# Altium schematic sheet MAIN.SchDoc(MAIN)
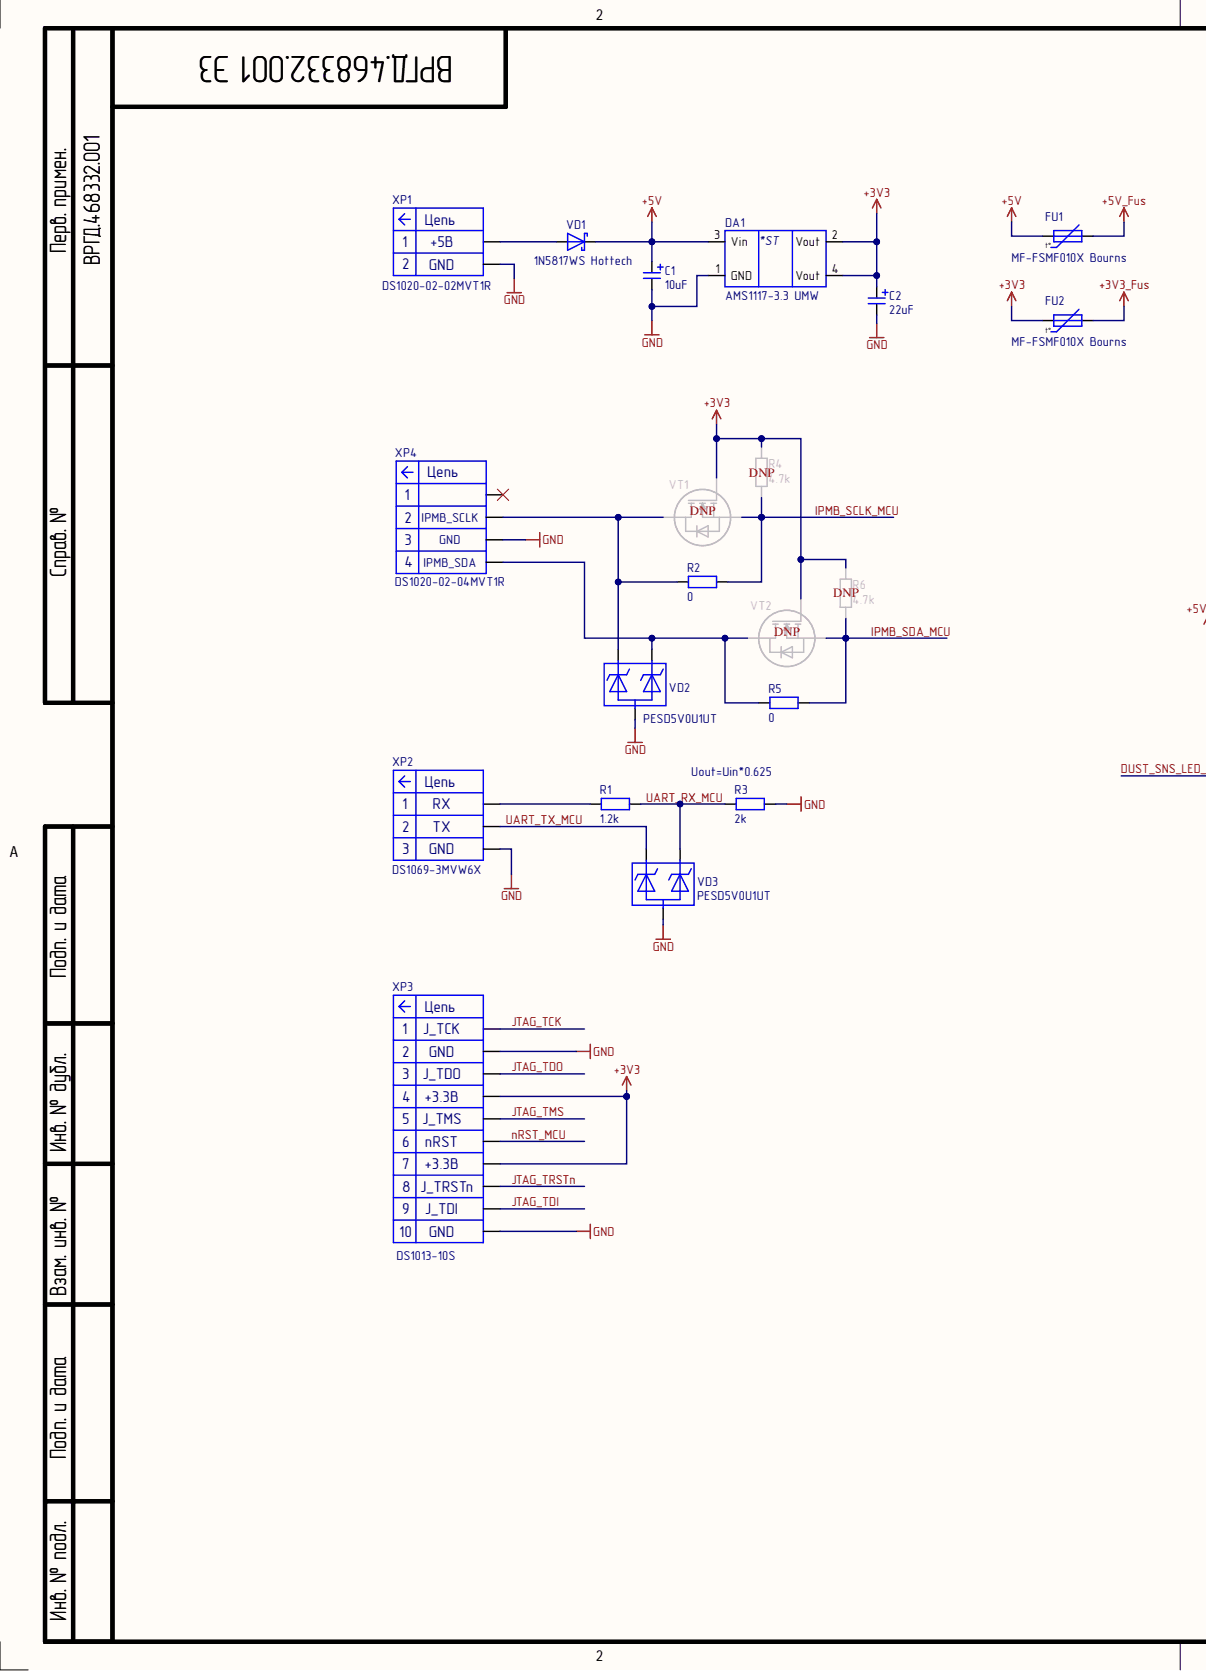
[diagram p0: MAIN.SchDoc, left]
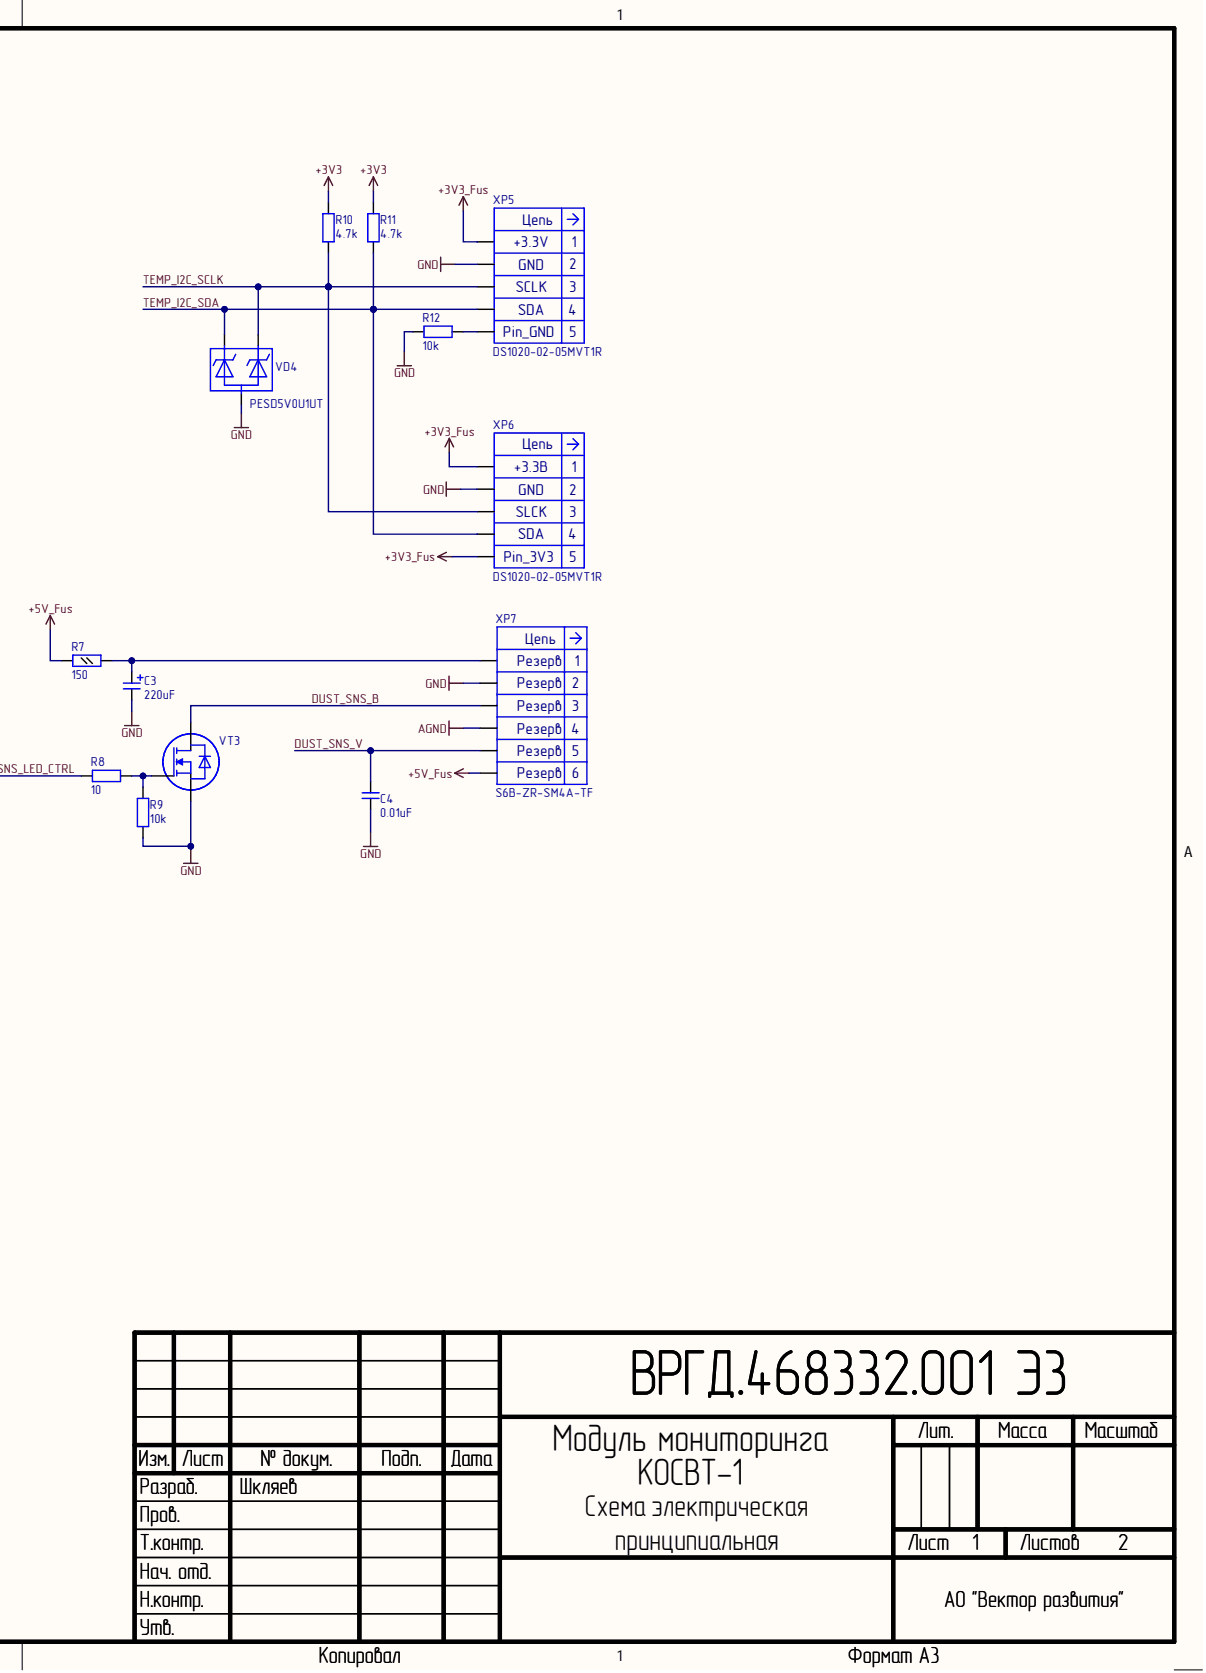
[diagram p1: MAIN.SchDoc, right]

## Parts
C1  10uF  [CAP ALSMD ELEC 4.3MM H5.4MM]
C2  22uF  [CAP ALSMD ELEC 4.3MM H5.4MM]
C3  220uF  [CAP ALSMD ELEC 6.6MM H7.7MM]
C4  0.01uF  [CAP 0603_1608]
DA1  AMS1117-3.3 UMW  Manufacturer: UMW  Name: AMS1117-3.3  [DIODES SOT-223]
FU1  MF-FSMF010X Bourns  Manufacturer: Bourns  Name: MF-FSMF010X  [FUSE 0603_1608 0.5MM]
FU2  MF-FSMF010X Bourns  Manufacturer: Bourns  Name: MF-FSMF010X  [FUSE 0603_1608 0.5MM]
R1  1.2k  [RES 0603_1608]
R2  0  [RES 0603_1608]
R3  2k  [RES 0603_1608]
R4  4.7k  [RES 0603_1608]
R5  0  [RES 0603_1608]
R6  4.7k  [RES 0603_1608]
R7  150  [RES 0805_2012]
R8  10  [RES 0603_1608]
R9  10k  [RES 0603_1608]
R10  4.7k  [RES 0603_1608]
R11  4.7k  [RES 0603_1608]
R12  10k  [RES 0603_1608]
VD1  1N5817WS Hottech  Manufacturer: Hottech  Name: 1N5817WS  [MCC SOD-323]
VD2  PESD5V0U1UT  [SOT-23-3 N]
VD3  PESD5V0U1UT  [SOT-23-3 N]
VD4  PESD5V0U1UT  [SOT-23-3 N]
VT1  2П526А9 АЕЯР.432140.897ТУ  [SOT-23-3 N]  (value not drawn)
VT2  2П526А9 АЕЯР.432140.897ТУ  [SOT-23-3 N]  (value not drawn)
VT3  2П526А9 АЕЯР.432140.897ТУ  [SOT-23-3 N]  (value not drawn)
XP1  DS1020-02-02MVT1R  Net_1: +5В  Net_2: GND  [DS1020-02-02MVT1R]
XP2  DS1069-3MVW6X  Net_1: RX  Net_2: TX  Net_3: GND  [CONNFLY_DS1069-3M]
XP3  DS1013-10S  Net_1: J_TCK  Net_2: GND  Net_3: J_TDO  Net_4: +3.3В  Net_5: J_TMS  Net_6: nRST  Net_8: J_TRSTn  Net_9: J_TDI  [DS1013-10S]
XP4  DS1020-02-04MVT1R  Net_2: IPMB_SCLK  Net_3: GND  Net_4: IPMB_SDA  [DS1020-02-04MVT1R]
XP5  DS1020-02-05MVT1R  Net_1: +3.3V  Net_2: GND  Net_3: SCLK  Net_4: SDA  Net_5: Pin_GND  [DS1020-02-05MVT1R]
XP6  DS1020-02-05MVT1R  Net_1: +3.3В  Net_2: GND  Net_3: SLCK  Net_4: SDA  Net_5: Pin_3V3  [DS1020-02-05MVT1R]
XP7  S6B-ZR-SM4A-TF  Net_1: Резерв  [JST S6B-ZR-SM4A-TF]

## Nets
+3V3: C2.1 DA1.2(Vout) DA1.4(Vout) FU2.1 R4.2 R6.2 R10.2 R11.2 VT1.1 VT2.1 XP3.4(+3.3В) XP3.7(+3.3В)
+3V3_Fus: FU2.2 XP5.1(+3.3V) XP6.1(+3.3В) XP6.5(Pin_3V3)
+5V: C1.1 DA1.3(Vin) FU1.1 VD1.2
+5V_Fus: FU1.2 R7.1 XP7.6(Резерв)
AGND: XP7.4(Резерв)
GND: C1.2 C2.2 C3.2 C4.2 DA1.1(GND) R3.2 R9.1 R12.2 VD2.3 VD3.3 VD4.3 VT3.3 XP1.2 XP2.3 XP3.2 XP3.10 XP4.3 XP5.2(GND) XP6.2(GND) XP7.2(Резерв)
DUST_SNS_B: VT3.2 XP7.3(Резерв)
DUST_SNS_LED_CTRL: R8.1
DUST_SNS_V: C4.1 XP7.5(Резерв)
IPMB_SCLK_MCU: R2.1 R4.1 VT1.3
IPMB_SDA_MCU: R5.1 R6.1 VT2.3
JTAG_TCK: XP3.1(J_TCK)
JTAG_TDI: XP3.9(J_TDI)
JTAG_TDO: XP3.3(J_TDO)
JTAG_TMS: XP3.5(J_TMS)
JTAG_TRSTn: XP3.8(J_TRSTn)
nRST_MCU: XP3.6(nRST)
TEMP_I2C_SCLK: R10.1 VD4.2 XP5.3(SCLK) XP6.3(SLCK)
TEMP_I2C_SDA: R11.1 VD4.1 XP5.4(SDA) XP6.4(SDA)
UART_RX_MCU: R1.2 R3.1 VD3.2
UART_TX_MCU: VD3.1 XP2.2
NetC3_1: C3.1 R7.2 XP7.1(Резерв)
NetR1_1: R1.1 XP2.1
NetR2_2: R2.2 VD2.1 VT1.2 XP4.2(IPMB_SCLK)
NetR5_2: R5.2 VD2.2 VT2.2 XP4.4(IPMB_SDA)
NetR8_2: R8.2 R9.2 VT3.1
NetR12_1: R12.1 XP5.5(Pin_GND)
NetVD1_1: VD1.1 XP1.1

Not connected: XP4 1

## Library data of the parts
C1 = К50-97 – 25В – 10мкФ (±20) % – ЕВАЯ.673541.054ТУ: Конденсатор К50-97 – 25В – 10мкФ (±20) % – ЕВАЯ.673541.054ТУ; Manufacturer: АО Элеконд; Database Table Name: capacitors.csv; Dielectric type: Electrolytic; Group: Конденсаторы полярные; Tolerance: ± 20%; Type: Конденсатор электролитический; Voltage: 25V; Component Kind: Standard; Country: Россия; HelpURL: https://elecond.ru/pdf/k50-97.pdf; Library Name: ComponentsDb.DbLib; Manufacturer Part Number: К50-97 – 25В – 10мкФ (±20) % – ЕВАЯ.673541.054ТУ; Name: К50-97 – 25В – 10мкФ (±20) % – ЕВАЯ.673541.054ТУ; Package: SMD D4x5.4; Value: 10uF
C2 = К50-97 – 16В – 22мкФ (±20) % – ЕВАЯ.673541.054ТУ: Конденсатор К50-97 – 16В – 22мкФ (±20) % – ЕВАЯ.673541.054ТУ; Manufacturer: АО Элеконд; Database Table Name: capacitors.csv; Dielectric type: Electrolytic; Group: Конденсаторы полярные; Tolerance: ± 20%; Type: Конденсатор электролитический; Voltage: 16V; Component Kind: Standard; Country: Россия; HelpURL: https://elecond.ru/pdf/k50-97.pdf; Library Name: ComponentsDb.DbLib; Manufacturer Part Number: К50-97 – 16В – 22мкФ (±20) % – ЕВАЯ.673541.054ТУ; Name: К50-97 – 16В – 22мкФ (±20) % – ЕВАЯ.673541.054ТУ; Package: SMD D4x5.4; Value: 22uF
C3 = К50-97 – 16В – 220мкФ (±20) % – ЕВАЯ.673541.054ТУ: Конденсатор К50-97 – 16В – 220мкФ (±20) % – ЕВАЯ.673541.054ТУ; Manufacturer: АО Элеконд; Database Table Name: capacitors.csv; Dielectric type: Electrolytic; Group: Конденсаторы полярные; Tolerance: ± 20%; Type: Конденсатор электролитический; Voltage: 16V; Component Kind: Standard; Country: Россия; HelpURL: https://elecond.ru/pdf/k50-97.pdf; Library Name: ComponentsDb.DbLib; Manufacturer Part Number: К50-97 – 16В – 220мкФ (±20) % – ЕВАЯ.673541.054ТУ; Name: К50-97 – 16В – 220мкФ (±20) % – ЕВАЯ.673541.054ТУ; Package: SMD D6.3x7.7; Value: 220uF
C4 = К10-83 - 50 В - 0,01 мкФ ±20 % - Н20 - N - 1 АЖЯР.673511.008 ТУ: Конденсатор К10-83 - 50 В - 0,01 мкФ ±20 % - Н20 - N - 1 АЖЯР.673511.008 ТУ; Manufacturer: АО «НИИ «Гириконд»; Database Table Name: capacitors.csv; Group: Конденсаторы; Tolerance: ± 20%; Type: Конденсатор керамический; Voltage: 50V; Component Kind: Standard; Country: Россия; Dielectric type: Н20; HelpURL: https://giricond.ru/upload/pdf/K/K10-83.pdf; Library Name: ComponentsDb.DbLib; Manufacturer Part Number: К10-83 - 50 В - 0,01 мкФ ±20 % - Н20 - N - 1 АЖЯР.673511.008 ТУ; Name: К10-83 - 50 В - 0,01 мкФ ±20 % - Н20 - N - 1 АЖЯР.673511.008 ТУ; Package: SMD0603; Value: 0.01uF
DA1 = AMS1117-3.3 UMW: Микросхема AMS1117-3.3; Database Table Name: regulator_ics.csv; Group: Микросхемы; Input Voltage: 4.5 to 12V; Max Temperature: 125°С; Min Temperature: -40°С; Output Current: 1A; Output Voltage: 3.3V; Component Kind: Standard; Country: Китай; HelpURL: https://www.lcsc.com/datasheet/lcsc_datasheet_2412201207_UMW-Youtai-Semiconductor-Co---Ltd--AMS1117-3-3_C347222.pdf; Library Name: ComponentsDb.DbLib; Manufacturer Part Number: AMS1117-3.3 UMW; Package: SOT-223; Type: Линейный регулятор
FU1 FU2 = MF-FSMF010X Bourns: Предохранитель самовосстанавливающийся MF-FSMF010X; Database Table Name: fuses.csv; Group: Предохранители; I hold: 0.1A; I trip: 0.3A; V: 15V; Component Kind: Standard; Country: США; HelpURL: https://static.chipdip.ru/lib/299/DOC012299906.pdf; Library Name: ComponentsDb.DbLib; Manufacturer Part Number: MF-FSMF010X Bourns; Package: SMD0603; Type: Предохранитель самовосстанавливающийся
R1 = Резистор Р1-12 - 0,1 - 1,2кОм - ±1 % - У - "А" АЛЯР.434110.005 ТУ: Manufacturer: АО «НПО «ЭРКОН»; Database Table Name: resistors.csv; Group: Резисторы; Power: 0.1W; Tolerance: ± 1%; Voltage: 50V; Component Kind: Standard; Country: Россия; Description: Резистор Р1-12 - 0,1 - 1,2кОм - ±1 % - У - "А" АЛЯР.434110.005 ТУ; HelpURL: https://www.erkon-nn.ru/upload/model_library/R1-12/r1-12_5.pdf; Library Name: ComponentsDb.DbLib; Manufacturer Part Number: Резистор Р1-12 - 0,1 - 1,2кОм - ±1 % - У - "А" АЛЯР.434110.005 ТУ; Name: Резистор Р1-12 - 0,1 - 1,2кОм - ±1 % - У - "А" АЛЯР.434110.005 ТУ; Package: SMD0603; Type: Резистор; Value: 1.2k
R2 R5 = Резистор Р1-12М - 0603 - 0 - А - М - АБШК.434110.023 ТУ: Manufacturer: АО «НПО «ЭРКОН»; Database Table Name: resistors.csv; Group: Резисторы; Component Kind: Standard; Country: Россия; Description: Резистор Р1-12М - 0603 - 0 - А - М - АБШК.434110.023 ТУ; HelpURL: https://www.erkon-nn.ru/upload/model_library/R1-12/r1-12_5.pdf; Library Name: ComponentsDb.DbLib; Manufacturer Part Number: Резистор Р1-12М - 0603 - 0 - А - М - АБШК.434110.023 ТУ; Name: Р1-12М - 0603 - 0 - А - М - АБШК.434110.023 ТУ; Package: SMD0603; Type: Резистор; Value: 0
R3 = Резистор Р1-12 - 0,1 - 2кОм - ±1 % - У - "А" АЛЯР.434110.005 ТУ: Manufacturer: АО «НПО «ЭРКОН»; Database Table Name: resistors.csv; Group: Резисторы; Power: 0.1W; Tolerance: ± 1%; Voltage: 50V; Component Kind: Standard; Country: Россия; Description: Резистор Р1-12 - 0,1 - 2кОм - ±1 % - У - "А" АЛЯР.434110.005 ТУ; HelpURL: https://www.erkon-nn.ru/upload/model_library/R1-12/r1-12_5.pdf; Library Name: ComponentsDb.DbLib; Manufacturer Part Number: Резистор Р1-12 - 0,1 - 2кОм - ±1 % - У - "А" АЛЯР.434110.005 ТУ; Name: Резистор Р1-12 - 0,1 - 2кОм - ±1 % - У - "А" АЛЯР.434110.005 ТУ; Package: SMD0603; Type: Резистор; Value: 2k
R4 R6 R10 R11 = Резистор Р1-12 - 0,1 - 4,7кОм - ±1 % - У - "А" АЛЯР.434110.005 ТУ: Manufacturer: АО «НПО «ЭРКОН»; Database Table Name: resistors.csv; Group: Резисторы; Power: 0.1W; Tolerance: ± 1%; Voltage: 50V; Component Kind: Standard; Country: Россия; Description: Резистор Р1-12 - 0,1 - 4,7кОм - ±1 % - У - "А" АЛЯР.434110.005 ТУ; HelpURL: https://www.erkon-nn.ru/upload/model_library/R1-12/r1-12_5.pdf; Library Name: ComponentsDb.DbLib; Manufacturer Part Number: Резистор Р1-12 - 0,1 - 4,7кОм - ±1 % - У - "А" АЛЯР.434110.005 ТУ; Name: Резистор Р1-12 - 0,1 - 4,7кОм - ±1 % - У - "А" АЛЯР.434110.005 ТУ; Package: SMD0603; Type: Резистор; Value: 4.7k
R7 = Резистор Р1-12 - 0,125 - 150Ом - ±1 % - У - "А" АЛЯР.434110.005 ТУ: Manufacturer: АО «НПО «ЭРКОН»; Database Table Name: resistors.csv; Group: Резисторы; Power: 0.125W; Tolerance: ± 1%; Voltage: 150V; Component Kind: Standard; Country: Россия; Description: Резистор Р1-12 - 0,125 - 150Ом - ±1 % - У - "А" АЛЯР.434110.005 ТУ; HelpURL: https://www.erkon-nn.ru/upload/model_library/R1-12/r1-12_5.pdf; Library Name: ComponentsDb.DbLib; Manufacturer Part Number: Резистор Р1-12 - 0,125 - 150Ом - ±1 % - У - "А" АЛЯР.434110.005 ТУ; Name: Резистор Р1-12 - 0,125 - 150Ом - ±1 % - У - "А" АЛЯР.434110.005 ТУ; Package: SMD0805; Type: Резистор; Value: 150
R8 = Резистор Р1-12 - 0,1 - 10Ом - ±1 % - У - "А" АЛЯР.434110.005 ТУ: Manufacturer: АО «НПО «ЭРКОН»; Database Table Name: resistors.csv; Group: Резисторы; Power: 0.1W; Tolerance: ± 1%; Voltage: 50V; Component Kind: Standard; Country: Россия; Description: Резистор Р1-12 - 0,1 - 10Ом - ±1 % - У - "А" АЛЯР.434110.005 ТУ; HelpURL: https://www.erkon-nn.ru/upload/model_library/R1-12/r1-12_5.pdf; Library Name: ComponentsDb.DbLib; Manufacturer Part Number: Резистор Р1-12 - 0,1 - 10Ом - ±1 % - У - "А" АЛЯР.434110.005 ТУ; Name: Резистор Р1-12 - 0,1 - 10Ом - ±1 % - У - "А" АЛЯР.434110.005 ТУ; Package: SMD0603; Type: Резистор; Value: 10
R9 R12 = Резистор Р1-12 - 0,1 - 10кОм - ±1 % - У - "А" АЛЯР.434110.005 ТУ: Manufacturer: АО «НПО «ЭРКОН»; Database Table Name: resistors.csv; Group: Резисторы; Power: 0.1W; Tolerance: ± 1%; Voltage: 50V; Component Kind: Standard; Country: Россия; Description: Резистор Р1-12 - 0,1 - 10кОм - ±1 % - У - "А" АЛЯР.434110.005 ТУ; HelpURL: https://www.erkon-nn.ru/upload/model_library/R1-12/r1-12_5.pdf; Library Name: ComponentsDb.DbLib; Manufacturer Part Number: Резистор Р1-12 - 0,1 - 10кОм - ±1 % - У - "А" АЛЯР.434110.005 ТУ; Name: Резистор Р1-12 - 0,1 - 10кОм - ±1 % - У - "А" АЛЯР.434110.005 ТУ; Package: SMD0603; Type: Резистор; Value: 10k
VD1 = 1N5817WS Hottech: Диод Шоттки 1N5817WS; Database Table Name: diodes.csv; Group: Диоды; Max If: 1A; Max Vr: 20V; Component Kind: Standard; Country: Китай; Library Name: ComponentsDb.DbLib; Manufacturer Part Number: 1N5817WS Hottech; Package: SOD-323; Type: Диод Шоттки
VD2-VD4 = PESD5V0U1UT: Диод ESD PESD5V0U1UT; Manufacturer: UTD Semiconductor; Database Table Name: diodes.csv; Group: Диоды; Type: Сборка ESD диодов; Vrwm: 5V; Component Kind: Standard; Country: Китай; Library Name: ComponentsDb.DbLib; Manufacturer Part Number: PESD5V0U1UT; Name: PESD5V0U1UT; Package: SOT23-132
VT1-VT3 = 2П526А9 АЕЯР.432140.897ТУ: Транзистор 2П526А9 АЕЯР.432140.897ТУ; Manufacturer: Кремний Эл; Database Table Name: transistors.csv; Group: Транзисторы; Id/Ic: 1.2A; Struct: N-MOSFET; Type: Транзистор полевой; Vds/Vce: 30V; Vth: 2V; Component Kind: Standard; Country: Россия; HelpURL: https://group-kremny.ru/catalog/diskretnye-poluprovodniki/polevye-tranzistory/2p526a9/; Library Name: ComponentsDb.DbLib; Manufacturer Part Number: 2П526А9 АЕЯР.432140.897ТУ; Name: 2П526А9 АЕЯР.432140.897ТУ; Package: SOT-23-132
XP1 = DS1020-02-02MVT1R: Соединитель DS1020-02-02MVT1R; Manufacturer: Connfly; Database Table Name: connectors.csv; Group: Соединители; Max Temperature: 105°С; Min Temperature: -40°С; Nominal Voltage: 250V; Pins number: 2; Rows: 1; Component Kind: Standard; Country: Китай; Library Name: ComponentsDb.DbLib; Manufacturer Part Number: DS1020-02-02MVT1R; Name: DS1020-02-02MVT1R; Type: Вилка угловая
XP2 = DS1069-3MVW6X: Соединитель DS1069-3MVW6X; Manufacturer: Connfly; Database Table Name: connectors.csv; Group: Соединители; Max Temperature: 85°С; Min Temperature: -25°С; Nominal current: 3A; Nominal Voltage: 250V; Pins number: 3; Rows: 1; Component Kind: Standard; Country: Китай; Library Name: ComponentsDb.DbLib; Manufacturer Part Number: DS1069-3MVW6X; Name: DS1069-3MVW6X; Type: Вилка прямая
XP3 = DS1013-10S: Соединитель DS1013-10S; Manufacturer: Connfly; Database Table Name: connectors.csv; Group: Соединители; Max Temperature: 105°С; Min Temperature: -40°С; Nominal current: 1A; Nominal Voltage: 500V; Pins number: 10; Rows: 2; Component Kind: Standard; Country: Китай; Library Name: ComponentsDb.DbLib; Manufacturer Part Number: DS1013-10S; Name: DS1013-10S; Net_7: +3.3В; Net_10: GND; Type: Вилка прямая
XP4 = DS1020-02-04MVT1R: Соединитель DS1020-02-04MVT1R; Manufacturer: Connfly; Database Table Name: connectors.csv; Group: Соединители; Max Temperature: 105°С; Min Temperature: -40°С; Net_1: Резерв; Nominal Voltage: 250V; Pins number: 4; Rows: 1; Component Kind: Standard; Country: Китай; Library Name: ComponentsDb.DbLib; Manufacturer Part Number: DS1020-02-04MVT1R; Name: DS1020-02-04MVT1R; Type: Вилка угловая
XP5 XP6 = DS1020-02-05MVT1R: Соединитель DS1020-02-05MVT1R; Manufacturer: Connfly; Database Table Name: connectors.csv; Group: Соединители; Max Temperature: 105°С; Min Temperature: -40°С; Nominal Voltage: 250V; Pins number: 5; Rows: 1; Component Kind: Standard; Country: Китай; Library Name: ComponentsDb.DbLib; Manufacturer Part Number: DS1020-02-05MVT1R; Name: DS1020-02-05MVT1R; Type: Вилка угловая
XP7 = S6B-ZR-SM4A-TF: Соединитель S6B-ZR-SM4A-TF; Manufacturer: JST; Database Table Name: connectors.csv; Group: Соединители; Max Temperature: 85°С; Min Temperature: -25°С; Nominal current: 1A; Nominal Voltage: 50V; Pins number: 6; Rows: 1; Component Kind: Standard; Country: Китай; Library Name: ComponentsDb.DbLib; Manufacturer Part Number: S6B-ZR-SM4A-TF; Name: S6B-ZR-SM4A-TF; Net_2: Резерв; Net_3: Резерв; Net_4: Резерв; Net_5: Резерв; Net_6: Резерв; Type: Вилка угловая
